# Altium schematic sheet Fan FETs and Thermo.SchDoc
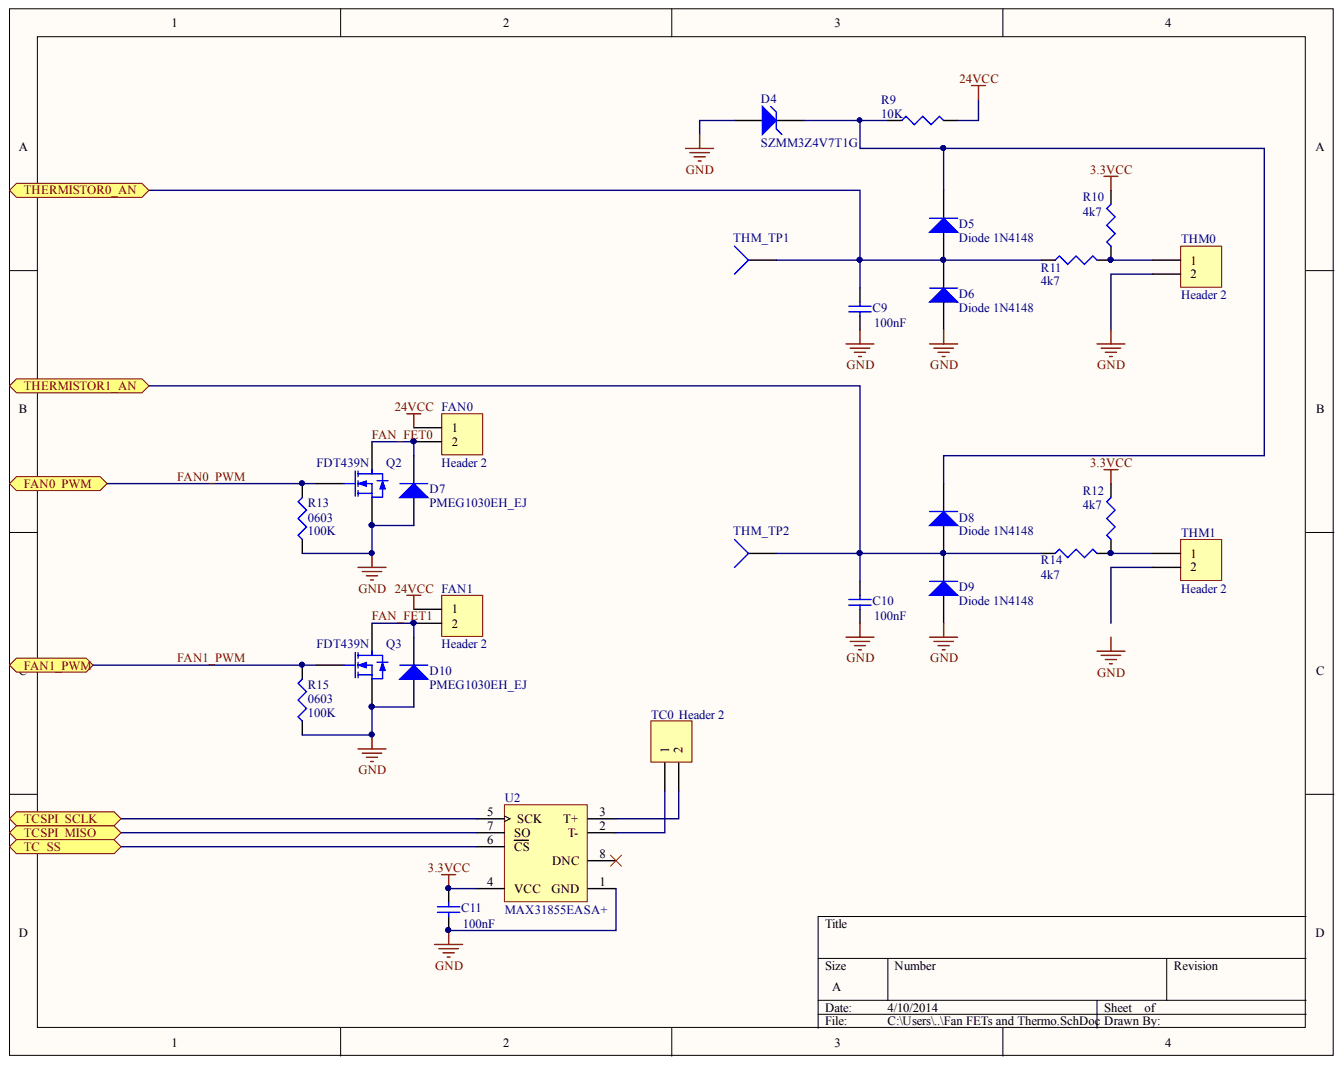
[diagram p0: Fan FETs and Thermo.SchDoc]

## Parts
C9  value 100nF  (hidden comment: Cap Semi)
C10  value 100nF  (hidden comment: Cap Semi)
C11  value 100nF  (hidden comment: Cap Semi)
D4  SZMM3Z4V7T1G
D5  Diode 1N4148
D6  Diode 1N4148
D7  PMEG1030EH_EJ
D8  Diode 1N4148
D9  Diode 1N4148
D10  PMEG1030EH_EJ
FAN0  Header 2
FAN1  Header 2
Q2  FDT439N
Q3  FDT439N
R9  value 10K  (hidden comment: Res3)
R10  value 4k7  (hidden comment: Res3)
R11  value 4k7  (hidden comment: Res3)
R12  value 4k7  (hidden comment: Res3)
R13  0603  value 100K
R14  value 4k7  (hidden comment: Res3)
R15  0603  value 100K
TC0  Header 2
THM0  Header 2
THM1  Header 2
THM_TP1  TP4  (value not drawn)
THM_TP2  TP4  (value not drawn)
U2  MAX31855EASA+

## Ports
FAN0_PWM, FAN1_PWM, TC_SS, TCSPI_MISO, TCSPI_SCLK, THERMISTOR0_AN, THERMISTOR1_AN

## Nets
2: Q2.4 Q3.4
3.3VCC: C11.2 R10.2 R12.2 U2.4(VCC)
24VCC: FAN0.1 FAN1.1 R9.2
GND: C9.1 C10.1 C11.1 D4.1 D6.1 D7.1 D9.1 D10.1 Q2.3 Q3.3 R13.1 R15.1 THM0.2 U2.1(GND)
FAN0_PWM: Q2.1 R13.2 ; port FAN0_PWM
FAN1_PWM: Q3.1 R15.2 ; port FAN1_PWM
FAN_FET0: D7.2 FAN0.2 Q2.2
FAN_FET1: D10.2 FAN1.2 Q3.2
TC_SS: U2.6(CS) ; port TC_SS
TCSPI_MISO: U2.7(SO) ; port TCSPI_MISO
TCSPI_SCLK: U2.5(SCK) ; port TCSPI_SCLK
THERMISTOR0_AN: C9.2 D5.1 D6.2 R11.1 THM_TP1.1 ; port THERMISTOR0_AN
THERMISTOR1_AN: C10.2 D8.1 D9.2 R14.1 THM_TP2.1 ; port THERMISTOR1_AN
NetD4_2: D4.2 D5.2 D8.2 R9.1
NetR10_1: R10.1 R11.2 THM0.1
NetR12_1: R12.1 R14.2 THM1.1
NetTC0_1: TC0.1 U2.2(T-)
NetTC0_2: TC0.2 U2.3(T+)

Not connected: THM1 2; U2 8(DNC)

## Library data of the parts
U2 = (no symbol name): Functions: Thermocouple-to-Digital Converter; Interface: SPI; Parasite Pwr.: No; DatasheetVersion: Rev. 2; PackageVersion: Rev. D, 10/2012; PackageReference: S8+4; PackageDescription: 8-Pin Small-Outline IC, Narrow, Body 3.9 x 4.9 mm, Pitch 1.27 mm; Supplier 1: Digi-Key; Supplier Part Number 1: MAX31855EASA+-ND; Supplier 2: Mouser; Supplier Part Number 2: 700-MAX31855EASA+; Generic Part Number: MAX31855; Mounting Technology: Surface Mount; Code_JEDEC: MS-012; PartNumber: MAX31855EASA+; ComponentLink1Description: Manufacturer URL; ComponentLink1URL: http://www.maximintegrated.com/; ComponentLink2Description: Datasheet; ComponentLink2URL: http://datasheets.maximintegrated.com/en/ds/MAX31855.pdf; ComponentLink3Description: Package Specification; ComponentLink3URL: http://pdfserv.maximintegrated.com/package_dwgs/21-0041.PDF
C9-C11 D4-D10 FAN0 FAN1 Q2 Q3 R9-R15 TC0 THM0 THM1 THM_TP1 THM_TP2 = (no symbol name): Publisher: Altium Limited
  C9-C11: Published: 8-Jun-2000; LatestRevisionDate: 29-May-2009; LatestRevisionNote: IPC-7351 Footprint Added.; PackageReference: C1206; PackageDescription: Chip Capacitor
  D4: Published: 8-Jun-2000; LatestRevisionDate: 17-Jul-2002; LatestRevisionNote: Re-released for DXP Platform.; PackageReference: DIODE-0.7; PackageDescription: Diode, Thru-Hole; 2 Leads; 0.7 in Pin Spacing
  D5 D6 D8 D9: Published: 8-Jun-2000; LatestRevisionDate: 17-Jul-2002; LatestRevisionNote: Re-released for DXP Platform.; DatasheetVersion: 1997; PackageReference: DO-35; PackageDescription: Diode, Axial; Body 3.8 x 1.9 mm (LxDia. max), Lead Dia. 0.53 mm (max); Code_JEDEC: DO-204-AH; ComponentLink1Description: Manufacturer Link; ComponentLink1URL: http://www.fairchildsemi.com/; ComponentLink2Description: Datasheet; ComponentLink2URL: http://www.fairchildsemi.com/ds/1N%2F1N4148.pdf
  D7 D10: Published: 8-Jun-2000; LatestRevisionDate: 17-Jul-2002; LatestRevisionNote: Re-released for DXP Platform.; PackageVersion: Sep-1996; PackageReference: SMC; PackageDescription: DO-214-AB/SMC; 2 C-Bend Leads; Body 7.9 x 5.9 mm, inc. leads (LxW); Code_JEDEC: DO-214-AB
  FAN0 FAN1 TC0 THM0 THM1 THM_TP1 THM_TP2: LatestRevisionDate: 17-Jul-2002; LatestRevisionNote: Re-released for DXP Platform.
  Q2 Q3: Published: 8-Jun-2000; LatestRevisionDate: 17-Jul-2002; LatestRevisionNote: Re-released for DXP Platform.; PackageVersion: 1995/1996; PackageReference: E3; PackageDescription: TO, Flat Index; 3 In-Line, Axial Leads; Body Dia. 4.6mm; Lead Dia. 0.5 mm (max)
  R9-R15: Published: 8-Jun-2000; LatestRevisionDate: 29-May-2009; LatestRevisionNote: IPC-7351 Footprint Added.; PackageReference: J1-0603; PackageDescription: Chip Resistor
